# Altium schematic sheet Pico.SchDoc
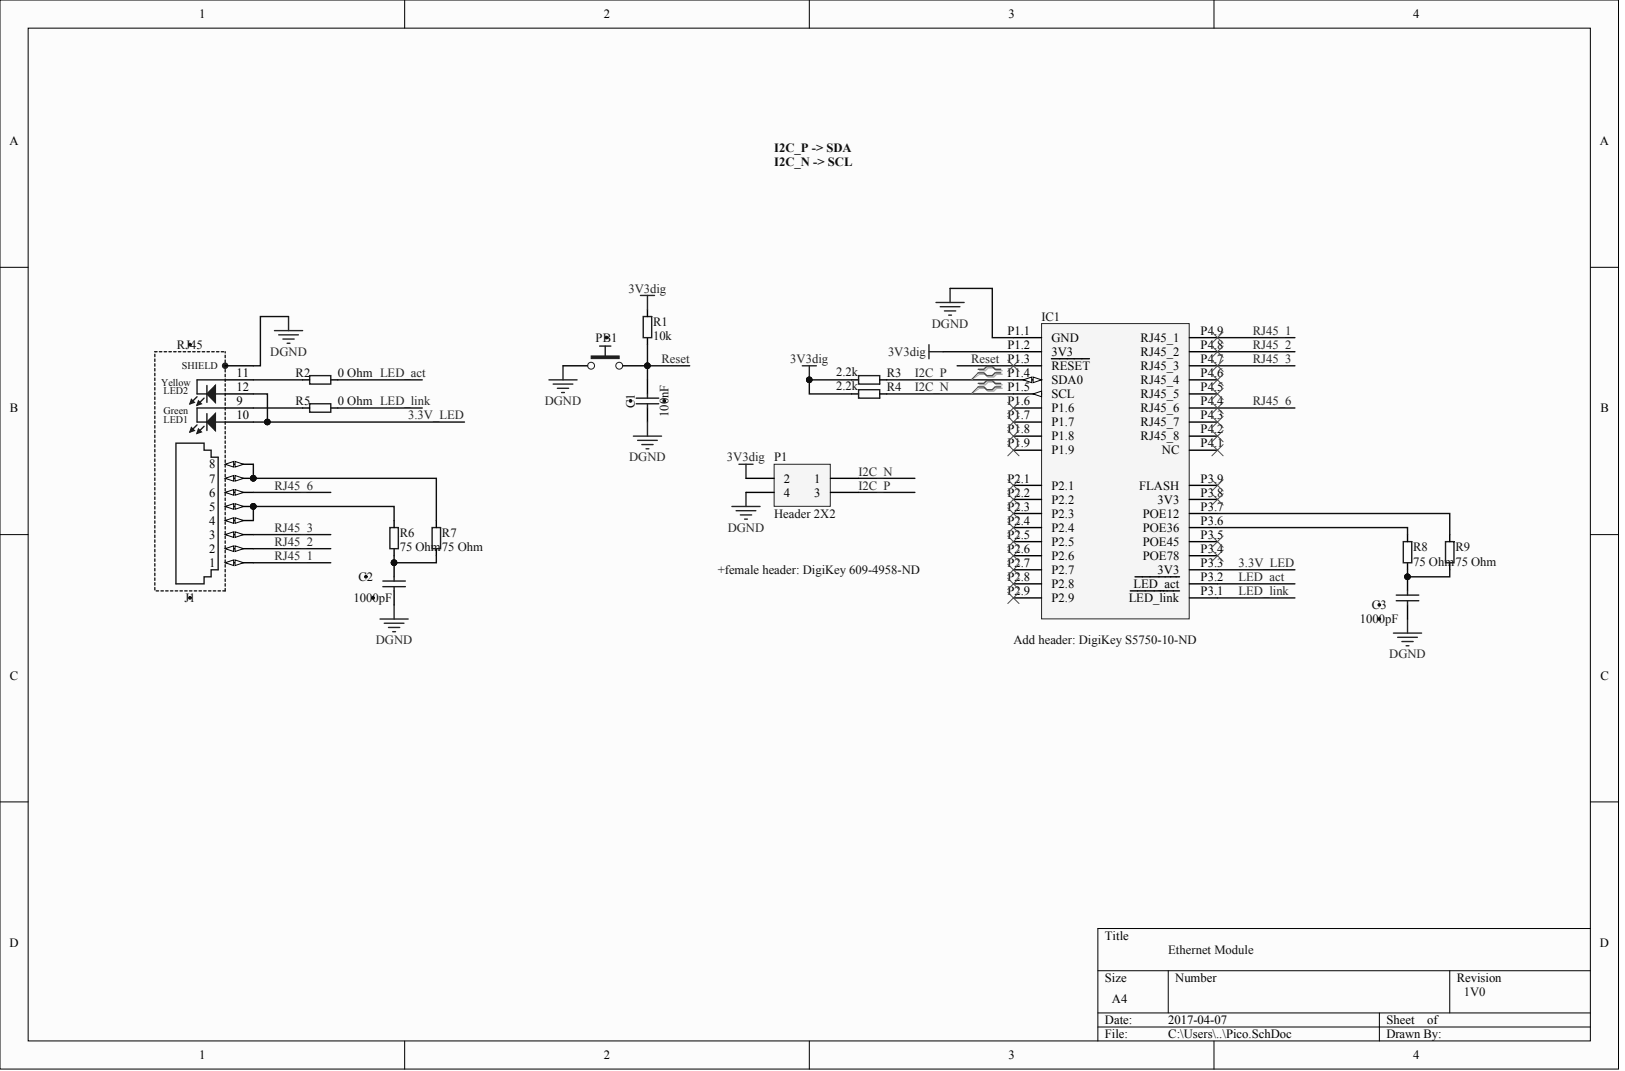
[diagram p0: Pico.SchDoc]

## Parts
C1  100nF  [CAPC1608X87N]
C2  1000pF  [CAPC1005X55N]
C3  1000pF  [CAPC1005X55N]
IC1  XT-PICO-XXL  (value not drawn)
J1  RJ45  [AMPHENOL_RJHSE-5381]
P1  Header 2X2
PB1  RESET  [PB_TYCO_FSMSM]  (value not drawn)
R1  Resistance (Ohms): 10k  (hidden comment: ERJ-2RKF2201X)
R2  Resistance (Ohms): 0 Ohm  (hidden comment: ERJ-2RKF2201X)
R3  Resistance (Ohms): 2.2k  (hidden comment: ERJ-2RKF2201X)
R4  Resistance (Ohms): 2.2k  (hidden comment: ERJ-2RKF2201X)
R5  Resistance (Ohms): 0 Ohm  (hidden comment: ERJ-2RKF2201X)
R6  ERJ-2RKF2201X  (value not drawn)
R7  Resistance (Ohms): 75 Ohm  (hidden comment: ERJ-2RKF2201X)
R8  ERJ-2RKF2201X  (value not drawn)
R9  Resistance (Ohms): 75 Ohm  (hidden comment: ERJ-2RKF2201X)

## Nets
3V3DIG: IC1.P1.2(3V3) P1.2 R1.1 R3.2 R4.2
DGND: C1.1 C2.1 C3.1 IC1.P1.1(GND) J1.SHIELD P1.4 PB1.1
3.3V_LED: IC1.P3.3(3V3) J1.10 J1.12
I2C_N: IC1.P1.5(SCL) P1.1 R4.1
I2C_P: IC1.P1.4(SDA0) P1.3 R3.1
LED_ACT: IC1.P3.2(LED_act) R2.2
LED_LINK: IC1.P3.1(LED_link) R5.2
RESET: C1.2 IC1.P1.3(RESET) PB1.2 R1.2
RJ45_1: IC1.P4.9(RJ45_1) J1.1
RJ45_2: IC1.P4.8(RJ45_2) J1.2
RJ45_3: IC1.P4.7(RJ45_3) J1.3
RJ45_6: IC1.P4.4(RJ45_6) J1.6
NetC2_2: C2.2 R6.2 R7.2
NetC3_2: C3.2 R8.2 R9.2
NetIC1_P3.6: IC1.P3.6(POE36) R8.1
NetIC1_P3.7: IC1.P3.7(POE12) R9.1
NetJ1_4: J1.4 J1.5 R6.1
NetJ1_7: J1.7 J1.8 R7.1
NetJ1_9: J1.9 R5.1
NetJ1_11: J1.11 R2.1

Not connected: IC1 P1.6 P1.7 P1.8 P1.9 P2.1 P2.2 P2.3 P2.4 P2.5 P2.6 P2.7 P2.8 P2.9 P3.4(POE78) P3.5(POE45) P3.8(3V3) P3.9(FLASH) P4.1(NC) P4.2(RJ45_8) P4.3(RJ45_7) P4.5(RJ45_5) P4.6(RJ45_4)

## Library data of the parts
C1 = (no symbol name): Part Description: SMD Multilayer Chip Ceramic Capacitor; Manufacturer: GENERIC; Manufacturer Part Number: C1206C104K4RACTU; Voltage: 16V; TC: X7R; Tolerance: ±10%; Table: Capacitors SMD; Component Kind: Standard; Component Type: Standard; Pin Count: 2; Value: 100nF; Case: 1206; Mounted: Yes; Socket: No; SMD: Yes; Manufacturer1 Example: KEMET; HelpURL: \\cern.ch\dfs\Applications\Altium\Datasheets\CC0603_X5R_KEMET.pdf; CreateDate: 03/12/2007; LatestRevisionDate: 03/12/2007; DigiKey part no.: 399-9305-1-ND; Author: CERN DEM JLC
C2 C3 = (no symbol name): Part Description: SMD Multilayer Chip Ceramic Capacitor; Manufacturer: GENERIC; Manufacturer Part Number: C0402T102K5RACTU; Manufacturer1 Part Number: GRM155R71C104KA88D; Voltage: 1000V; TC: X7R; Tolerance: ±10%; Table: Capacitors SMD; Component Kind: Standard; Component Type: Standard; Pin Count: 2; Value: 1000pF; Case: 0402; Mounted: Yes; Socket: No; SMD: Yes; Manufacturer1 Example: KEMET; HelpURL: \\cern.ch\dfs\Applications\Altium\Datasheets\CC0402_X7R_MURATA_GRM.pdf; CreateDate: 05/12/2013; LatestRevisionDate: 03/12/2007; DigiKey part no.: 399-12306-1-ND; Author: CERN DEM JLC
IC1 = (no symbol name): Manufacturer: GENERIC; Manufacturer part no.: 63453-118LF; Manufacturer Part Number (ethernet module): XT-PICO-XXL; DigiKey part no.: 609-2663-ND
J1 = (no symbol name): Part Description: 1 Port, 8 Positions, CAT5 RJ45 Modular Jack With Yellow And Green LEDs; Manufacturer: AMPHENOL; Part Number: AMPHENOL_RJHSE-5381; Component Kind: Standard; Component Type: Standard; Pin Count: 14; Family: RJ45; Mounted: Yes; Socket: No; SMD: No; Status: None; Manufacturer Part Number: RJHSE-5381; ComponentHeight: 13.46mm; HelpURL: \\cern.ch\dfs\Applications\Altium\Datasheets\AMPHENOL_RJHSE-5381.pdf; ComponentLink1URL: \\cern.ch\dfs\Applications\Altium\Datasheets\AMPHENOL_RJHSE-5381_Package.pdf; ComponentLink1Description: Package Drawing; CreateDate: 2013-01-28; LatestRevisionDate: 2013-03-11; Table: AMPHENOL; DigiKey part no.: RJHSE-5381-ND; Author: CERN DEM JLC
P1 = (no symbol name): Manufacturer part no.: 75869-330LF; LatestRevisionDate: 17-Jul-2002; LatestRevisionNote: Re-released for DXP Platform.; DigiKey part no.: 609-5121-ND; Publisher: Altium Limited
PB1 = (no symbol name): Part Description: 12VDC 50mA SPST Tactile  Push-Button Switch; Manufacturer: TYCO ELECTRONICS; Manufacturer Part Number: FSMSM or 1437566-3; Table: Switches; Part Number: PB_TYCO_FSMSM; Component Kind: Standard; Component Type: Standard; Pin Count: 2; PackageDescription: Body 3.5x6.0mm, Pushbutton Switch,  TYCO ALCOSWITCH FSMSM Serie; Family: Push Button; Mounted: Yes; Socket: No; SMD: Yes; ComponentHeight: 4.3mm; HelpURL: \\cern.ch\dfs\Applications\Altium\Datasheets\PB_TYCO_FSMSM.pdf; CreateDate: 2008-06-30; LatestRevisionDate: 2012-06-21; DigiKey part no.: 450-1758-1-ND; Author: CERN DEM JLC
R1 = (no symbol name): Manufacturer: Panasonic Electronic Components; Manufacturer Part Number: ERJ-8ENF1002V; Tolerance: ±1%; Power (Watts): 0.1W, 1/10W; Temperature Coefficient: ±100ppm/°C; Supplier 1: Digi-Key; RoHS: RoHS Compliant; Category: Resistors; Stock 1: 1302095; Pricing 1: 1=0.1, 50=0.015, 100=0.011, 250=0.0084, 500=0.00674, 1000=0.00496, 5000=0.00355 (USD); Packaging: Cut Tape (CT); Composition: Thick Film; Features: Automotive AEC-Q200; Operating Temperature: -55°C ~ 155°C; Package / Case: 1206; Supplier Device Package: 1206; Size / Dimension: 3.2mm x 1.6mm; Number of Terminations: 2; ComponentLink1URL: http://industrial.panasonic.com/www-cgi/jvcr13pz.cgi?E+PZ+3+AOA0002+ERJ2RKF2201X+7+WW; ComponentLink1Description: http://industrial.panasonic.com/www-cgi/jvcr13pz.cgi?E+PZ+3+AOA0002+ERJ2RKF2201X+7+WW; Supplier Part Number 1: P2.20KLCT-ND; DigiKey part no.: P10.0KFCT-ND
R2 R5 = (no symbol name): Manufacturer Part Number: RC0805JR-070RL; Manufacturer: GENERIC; Tolerance: ±1%; Power (Watts): 0.125W; Temperature Coefficient: ±100ppm/°C; Supplier 1: Digi-Key; Manufacturer example: Yageo; RoHS: RoHS Compliant; Category: Resistors; Pricing 1: 1=0.1, 50=0.015, 100=0.011, 250=0.0084, 500=0.00674, 1000=0.00496, 5000=0.00355 (USD); Packaging: Cut Tape (CT); Composition: Thick Film; Operating Temperature: -55°C ~ 155°C; Package / Case: 0805 (2012 Metric); Supplier Device Package: 0805; Size / Dimension: 2.00mm x 1.2mm; Number of Terminations: 2; DigiKey part no.: 311-0.0ARCT-ND
R3 R4 = (no symbol name): Manufacturer: GENERIC; Tolerance: ±1%; Power (Watts): 0.1W, 1/10W; Temperature Coefficient: ±100ppm/°C; Supplier 1: Digi-Key; Manufacturer Part Number: ERJ-2RKF2201X; RoHS: RoHS Compliant; Category: Resistors; Stock 1: 1302095; Pricing 1: 1=0.1, 50=0.015, 100=0.011, 250=0.0084, 500=0.00674, 1000=0.00496, 5000=0.00355 (USD); Packaging: Cut Tape (CT); Composition: Thick Film; Features: Automotive AEC-Q200; Operating Temperature: -55°C ~ 155°C; Package / Case: 0402 (1005 Metric); Supplier Device Package: 0402; Size / Dimension: 0.039" L x 0.020" W (1.00mm x 0.50mm); Number of Terminations: 2; ComponentLink1URL: http://industrial.panasonic.com/www-cgi/jvcr13pz.cgi?E+PZ+3+AOA0002+ERJ2RKF2201X+7+WW; ComponentLink1Description: http://industrial.panasonic.com/www-cgi/jvcr13pz.cgi?E+PZ+3+AOA0002+ERJ2RKF2201X+7+WW; Supplier Part Number 1: P2.20KLCT-ND; DigiKey part no.: P2.20KLCT-ND
R6 R8 = (no symbol name): Manufacturer: Yageo; Manufacturer Part Number: RC0402FR-0775RL; Tolerance: ±1%; Power (Watts): 0.1W, 1/10W; Temperature Coefficient: ±100ppm/°C; Resistance (Ohms): 75 Ohm; Supplier 1: Digi-Key; RoHS: RoHS Compliant; Category: Resistors; Stock 1: 1302095; Pricing 1: 1=0.1, 50=0.015, 100=0.011, 250=0.0084, 500=0.00674, 1000=0.00496, 5000=0.00355 (USD); Packaging: Cut Tape (CT); Composition: Thick Film; Operating Temperature: -55°C ~ 155°C; Package / Case: 0402 (1005 Metric); Supplier Device Package: 0402; Size / Dimension: 0.039" L x 0.020" W (1.00mm x 0.50mm); Number of Terminations: 2; DigiKey part no.: 311-75.0LRCT-ND
R7 R9 = (no symbol name): Manufacturer: Yageo; Manufacturer Part Number: RC0402FR-0775RL; Tolerance: ±1%; Power (Watts): 0.1W, 1/10W; Temperature Coefficient: ±100ppm/°C; Supplier 1: Digi-Key; RoHS: RoHS Compliant; Category: Resistors; Stock 1: 1302095; Pricing 1: 1=0.1, 50=0.015, 100=0.011, 250=0.0084, 500=0.00674, 1000=0.00496, 5000=0.00355 (USD); Packaging: Cut Tape (CT); Composition: Thick Film; Operating Temperature: -55°C ~ 155°C; Package / Case: 0402 (1005 Metric); Supplier Device Package: 0402; Size / Dimension: 0.039" L x 0.020" W (1.00mm x 0.50mm); Number of Terminations: 2; DigiKey part no.: 311-75.0LRCT-ND
